# Altium schematic sheet CV_Inputs_Switches.SchDoc(CV_Inputs_Switches)
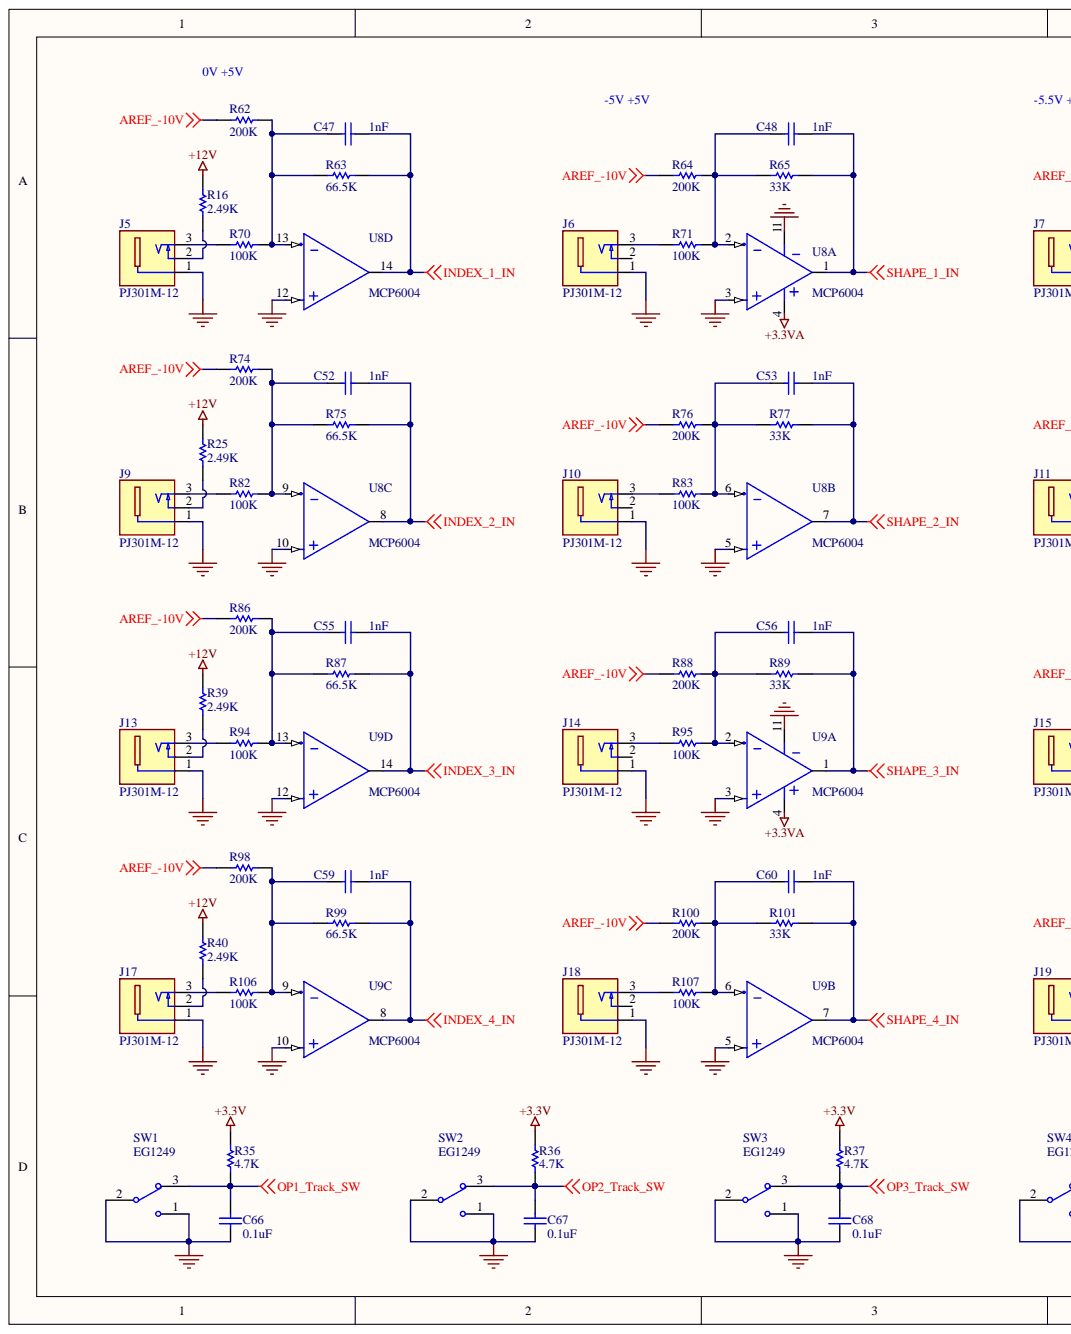
[diagram p0: CV_Inputs_Switches.SchDoc, left]
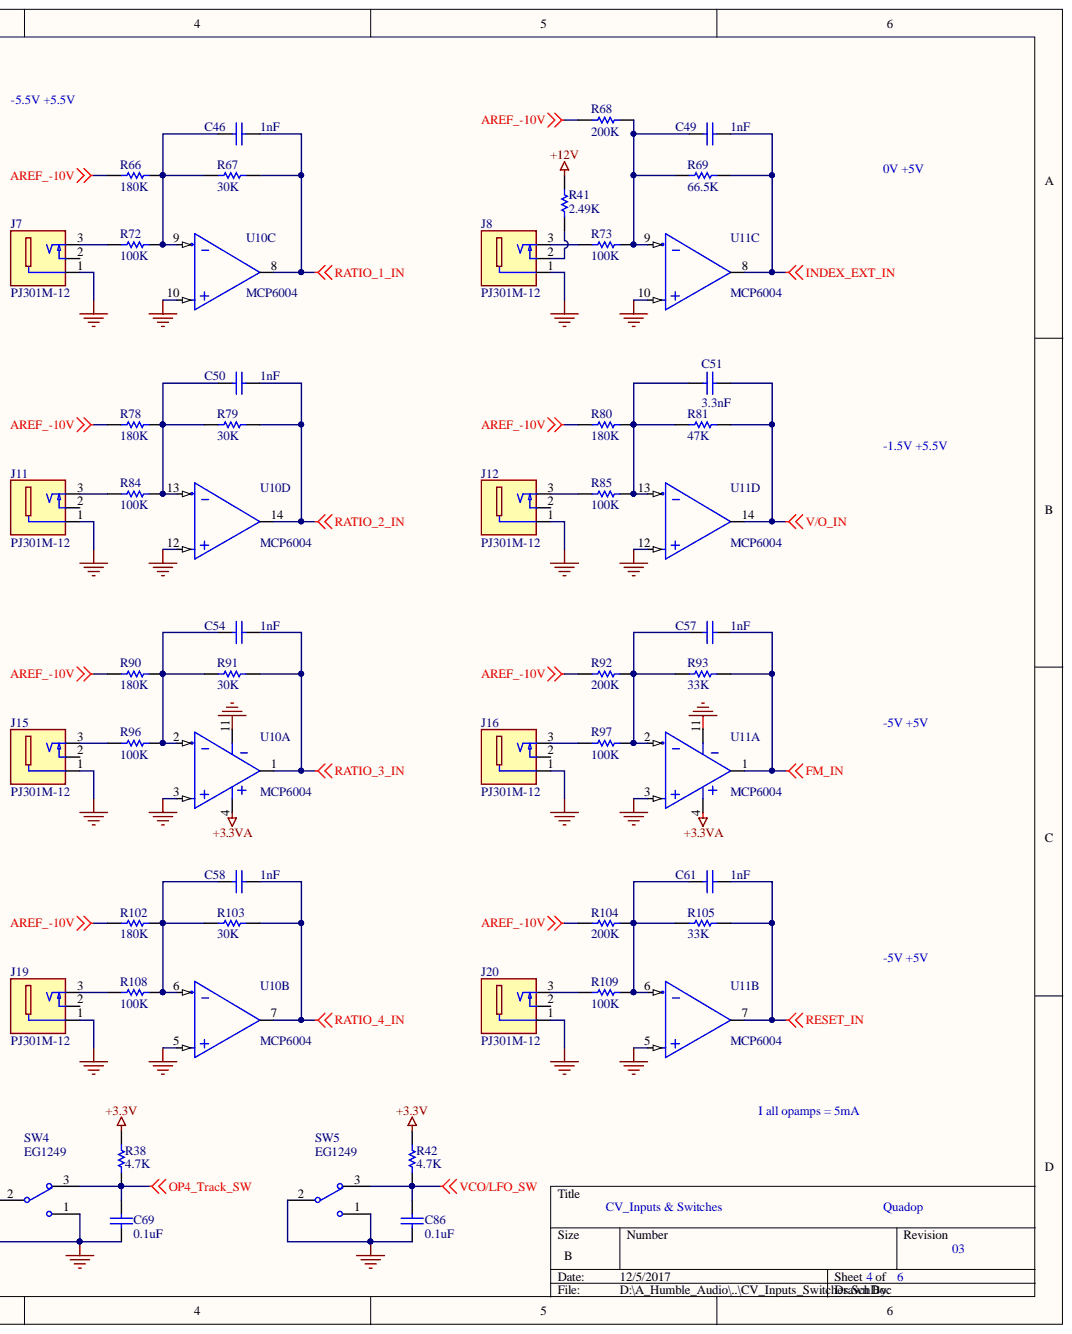
[diagram p1: CV_Inputs_Switches.SchDoc, right]

## Parts
C46  1nF  [C0603]
C47  1nF  [C0603]
C48  1nF  [C0603]
C49  1nF  [C0603]
C50  1nF  [C0603]
C51  3.3nF  [C0603]
C52  1nF  [C0603]
C53  1nF  [C0603]
C54  1nF  [C0603]
C55  1nF  [C0603]
C56  1nF  [C0603]
C57  1nF  [C0603]
C58  1nF  [C0603]
C59  1nF  [C0603]
C60  1nF  [C0603]
C61  1nF  [C0603]
C66  0.1uF  [C0603]
C67  0.1uF  [C0603]
C68  0.1uF  [C0603]
C69  0.1uF  [C0603]
C86  0.1uF  [C0603]
J5  PJ301M-12  [EP_J_3.5_PJ301M-12]
J6  PJ301M-12  [EP_J_3.5_PJ301M-12]
J7  PJ301M-12  [EP_J_3.5_PJ301M-12]
J8  PJ301M-12  [EP_J_3.5_PJ301M-12]
J9  PJ301M-12  [EP_J_3.5_PJ301M-12]
J10  PJ301M-12  [EP_J_3.5_PJ301M-12]
J11  PJ301M-12  [EP_J_3.5_PJ301M-12]
J12  PJ301M-12  [EP_J_3.5_PJ301M-12]
J13  PJ301M-12  [EP_J_3.5_PJ301M-12]
J14  PJ301M-12  [EP_J_3.5_PJ301M-12]
J15  PJ301M-12  [EP_J_3.5_PJ301M-12]
J16  PJ301M-12  [EP_J_3.5_PJ301M-12]
J17  PJ301M-12  [EP_J_3.5_PJ301M-12]
J18  PJ301M-12  [EP_J_3.5_PJ301M-12]
J19  PJ301M-12  [EP_J_3.5_PJ301M-12]
J20  PJ301M-12  [EP_J_3.5_PJ301M-12]
R16  2.49K  [R0603]
R25  2.49K  [R0603]
R35  4.7K  [R0603]
R36  4.7K  [R0603]
R37  4.7K  [R0603]
R38  4.7K  [R0603]
R39  2.49K  [R0603]
R40  2.49K  [R0603]
R41  2.49K  [R0603]
R42  4.7K  [R0603]
R62  200K  [R0603]
R63  66.5K  [R0603]
R64  200K  [R0603]
R65  33K  [R0603]
R66  180K  [R0603]
R67  30K  [R0603]
R68  200K  [R0603]
R69  66.5K  [R0603]
R70  100K  [R0603]
R71  100K  [R0603]
R72  100K  [R0603]
R73  100K  [R0603]
R74  200K  [R0603]
R75  66.5K  [R0603]
R76  200K  [R0603]
R77  33K  [R0603]
R78  180K  [R0603]
R79  30K  [R0603]
R80  180K  [R0603]
R81  47K  [R0603]
R82  100K  [R0603]
R83  100K  [R0603]
R84  100K  [R0603]
R85  100K  [R0603]
R86  200K  [R0603]
R87  66.5K  [R0603]
R88  200K  [R0603]
R89  33K  [R0603]
R90  180K  [R0603]
R91  30K  [R0603]
R92  200K  [R0603]
R93  33K  [R0603]
R94  100K  [R0603]
R95  100K  [R0603]
R96  100K  [R0603]
R97  100K  [R0603]
R98  200K  [R0603]
R99  66.5K  [R0603]
R100  200K  [R0603]
R101  33K  [R0603]
R102  180K  [R0603]
R103  30K  [R0603]
R104  200K  [R0603]
R105  33K  [R0603]
R106  100K  [R0603]
R107  100K  [R0603]
R108  100K  [R0603]
R109  100K  [R0603]
SW1  EG1249  [E-Switch_EG1249]
SW2  EG1249  [E-Switch_EG1249]
SW3  EG1249  [E-Switch_EG1249]
SW4  EG1249  [E-Switch_EG1249]
SW5  EG1249  [E-Switch_EG1249]
U8  MCP6004  [SO14]  (parts A,B,C,D)
U9  MCP6004  [SO14]  (parts A,B,C,D)
U10  MCP6004  [SO14]  (parts A,B,C,D)
U11  MCP6004  [SO14]  (parts A,B,C,D)

## Nets
+3.3V: R35.1 R36.1 R37.1 R38.1 R42.1
+3.3VA: U8.4 U9.4 U10.4 U11.4
+12V: R16.1 R25.1 R39.1 R40.1 R41.1
AREF_-10V: R62.1 R64.1 R66.1 R68.1 R74.1 R76.1 R78.1 R80.1 R86.1 R88.1 R90.1 R92.1 R98.1 R100.1 R102.1 R104.1
FM_IN: C57.2 R93.2 U11.1
GND: C66.2 C67.2 C68.2 C69.2 C86.2 J5.1 J6.1 J7.1 J8.1 J9.1 J10.1 J11.1 J12.1 J13.1 J14.1 J15.1 J16.1 J17.1 J18.1 J19.1 J20.1 SW1.1 SW1.2 SW2.1 SW2.2 SW3.1 SW3.2 SW4.1 SW4.2 SW5.1 SW5.2 U8.3 U8.5 U8.10 U8.11 U8.12 U9.3 U9.5 U9.10 U9.11 U9.12 U10.3 U10.5 U10.10 U10.11 U10.12 U11.3 U11.5 U11.10 U11.11 U11.12
INDEX_1_IN: C47.2 R63.2 U8.14
INDEX_2_IN: C52.2 R75.2 U8.8
INDEX_3_IN: C55.2 R87.2 U9.14
INDEX_4_IN: C59.2 R99.2 U9.8
INDEX_EXT_IN: C49.2 R69.2 U11.8
OP1_Track_SW: C66.1 R35.2 SW1.3
OP2_Track_SW: C67.1 R36.2 SW2.3
OP3_Track_SW: C68.1 R37.2 SW3.3
OP4_Track_SW: C69.1 R38.2 SW4.3
RATIO_1_IN: C46.2 R67.2 U10.8
RATIO_2_IN: C50.2 R79.2 U10.14
RATIO_3_IN: C54.2 R91.2 U10.1
RATIO_4_IN: C58.2 R103.2 U10.7
RESET_IN: C61.2 R105.2 U11.7
SHAPE_1_IN: C48.2 R65.2 U8.1
SHAPE_2_IN: C53.2 R77.2 U8.7
SHAPE_3_IN: C56.2 R89.2 U9.1
SHAPE_4_IN: C60.2 R101.2 U9.7
V/O_IN: C51.2 R81.2 U11.14
VCO/LFO_SW: C86.1 R42.2 SW5.3
NetC46_1: C46.1 R66.2 R67.1 R72.2 U10.9
NetC47_1: C47.1 R62.2 R63.1 R70.2 U8.13
NetC48_1: C48.1 R64.2 R65.1 R71.2 U8.2
NetC49_1: C49.1 R68.2 R69.1 R73.2 U11.9
NetC50_1: C50.1 R78.2 R79.1 R84.2 U10.13
NetC51_1: C51.1 R80.2 R81.1 R85.2 U11.13
NetC52_1: C52.1 R74.2 R75.1 R82.2 U8.9
NetC53_1: C53.1 R76.2 R77.1 R83.2 U8.6
NetC54_1: C54.1 R90.2 R91.1 R96.2 U10.2
NetC55_1: C55.1 R86.2 R87.1 R94.2 U9.13
NetC56_1: C56.1 R88.2 R89.1 R95.2 U9.2
NetC57_1: C57.1 R92.2 R93.1 R97.2 U11.2
NetC58_1: C58.1 R102.2 R103.1 R108.2 U10.6
NetC59_1: C59.1 R98.2 R99.1 R106.2 U9.9
NetC60_1: C60.1 R100.2 R101.1 R107.2 U9.6
NetC61_1: C61.1 R104.2 R105.1 R109.2 U11.6
NetJ5_2: J5.2 R16.2
NetJ5_3: J5.3 R70.1
NetJ6_3: J6.3 R71.1
NetJ7_3: J7.3 R72.1
NetJ8_2: J8.2 R41.2
NetJ8_3: J8.3 R73.1
NetJ9_2: J9.2 R25.2
NetJ9_3: J9.3 R82.1
NetJ10_3: J10.3 R83.1
NetJ11_3: J11.3 R84.1
NetJ12_3: J12.3 R85.1
NetJ13_2: J13.2 R39.2
NetJ13_3: J13.3 R94.1
NetJ14_3: J14.3 R95.1
NetJ15_3: J15.3 R96.1
NetJ16_3: J16.3 R97.1
NetJ17_2: J17.2 R40.2
NetJ17_3: J17.3 R106.1
NetJ18_3: J18.3 R107.1
NetJ19_3: J19.3 R108.1
NetJ20_3: J20.3 R109.1

Not connected: J6 2; J7 2; J10 2; J11 2; J12 2; J14 2; J15 2; J16 2; J18 2; J19 2; J20 2

## Library data of the parts
C46-C50 C52-C61 = 00102502: 1nF 50V 5% C0G 0603 -55+125; Manufacturer Part Number: C1608C0G1H102J080AA; Manufacturer: TDK; Tolerance: +-5%; PSpice: C; Database Table Name: Capacitor; Voltage: 50V; Value: 1nF; Tape Width: 8mm; SMD: Yes; Price: 0.0358; Part Type: Ceramic; Part Number: 00102502; Package: 4000T&R; Distributor Part Number: 445-1293-1-ND; Distributor: Digi-Key; Component Kind: Standard; Library Name: Gedo_DB.DbLib
C51 = 00332502: 3.3nF 50V 10% X7R 0603 -55+125; Manufacturer Part Number: CC0603KRX7R9BB332; Manufacturer: Yageo; Tolerance: +-10%; PSpice: C; Database Table Name: Capacitor; Voltage: 50V; Value: 3.3nF; Tape Width: 8mm; SMD: Yes; Price: 0.036; Part Type: Ceramic; Part Number: 00332502; Package: 4000T&R; Distributor Part Number: 311-1082-1-ND; Distributor: Digi-Key; Component Kind: Standard; Availability: In Stock; Library Name: Gedo_DB.DbLib
C66-C69 C86 = 00000538: 100nF 50V +-10% X7R 0603 -55+125; Manufacturer Part Number: GRM188R71H104KA93J; Manufacturer: MURATA; PSpice: C; Lead time: Stock; Database Table Name: Capacitor; Voltage: 50V; Value: 0.1uF; Tolerance: +-10%; Tape Width: 8mm; SMD: Yes; Price: 0.0048; Part Type: Ceramic; Part Number: 00000538; Package: 10000T&R330mm; MOQ: 4000; Main distributor: Rutronik; Distributor: Rutronik; Component Kind: Standard; Availability: In Stock; ActivepartsID: http://search.murata.co.jp/Ceramy/GrsearchViewAction.do;jsessionid=C4D720578E4D2E51EC807AFF78C1B5C3; Library Name: Gedo_DB.DbLib
J5-J20 = 72502011: 3pin EARPHONE JACK PANEL MOUNT VERTICAL F3.5; 0.5A 30V; Manufacturer: Thonkiconn; Database Table Name: Connectors; Value: PJ301M-12; Price: 0.48; Part Type: EarPhone jack F3.5; Part Number: 72502011; Manufacturer Part Number: PJ301M-12; Distributor: THONKICONN; Component Kind: Standard; Library Name: Gedo_DB.DbLib
R16 R25 R39-R41 = 11024912: 2K49 1% 0603 1/10W 100ppm; Manufacturer Part Number: RC0603FR-072K49L; Manufacturer: YAGEO; PSpice: R; Database Table Name: Resistor; Value: 2.49K; Tolerance: 1%; Tape Width: 8mm; SMD: Yes; Price: 0.012; Power: 1/10W; Part type: SMD 1%; Part Number: 11024912; Package: 5000T&R; Distributor Part Number: 311-2.49KHRTR-ND; Distributor: Digi-Key; Component Kind: Standard; Availability: In Stock; Library Name: Gedo_DB.DbLib
R35-R38 R42 = 11047012: 4K7 1% 0603 1/10W 100ppm; PSpice: R; Lead time: Stock; Database Table Name: Resistor; Value: 4.7K; Tolerance: 1%; Tape Width: 8mm; SMD: Yes; Power: 1/10W; Part type: SMD 1%; Part Number: 11047012; MOQ: 5000; Main distributor: Rutronik; Component Kind: Standard; Availability: In Stock; Library Name: Gedo_DB.DbLib
R62 R64 R68 R74 R76 R86 R88 R92 R98 R100 R104 = 11020032: 200K 1% 0603 1/10W 100ppm; Manufacturer: YAGEO; PSpice: R; Database Table Name: Resistor; Value: 200K; Tolerance: 1%; Tape Width: 8mm; SMD: Yes; Power: 1/10W; Part type: SMD 1%; Part Number: 11020032; Package: 5000T&R; Component Kind: Standard; Availability: In Stock; Library Name: Gedo_DB.DbLib
R63 R69 R75 R87 R99 = 11066522: 66K5 1% 0603 1/10W 100ppm; Manufacturer Part Number: RC0603FR-0766K5; Manufacturer: YAGEO; PSpice: R; Database Table Name: Resistor; Value: 66.5K; Tolerance: 1%; Tape Width: 8mm; SMD: Yes; Power: 1/10W; Part type: SMD 1%; Part Number: 11066522; Component Kind: Standard; Library Name: Gedo_DB.DbLib
R65 R77 R89 R93 R101 R105 = 11033022: 33K 1% 0603 1/10W 100ppm; Manufacturer Part Number: RC0603FR-0733KL; Manufacturer: YAGEO; PSpice: R; Database Table Name: Resistor; Value: 33K; Tolerance: 1%; Tape Width: 8mm; SMD: Yes; Price: 0.0057; Power: 1/10W; Part type: SMD 1%; Part Number: 11033022; Package: 5000T&R; Distributor Part Number: 311-33.0KHRCT-ND; Distributor: Digi-Key; Component Kind: Standard; Availability: In Stock; Library Name: Gedo_DB.DbLib
R66 R78 R80 R90 R102 = 11018032: 180K 1% 0603 1/10W 100ppm; Manufacturer Part Number: RC0603FR-07180KL; Manufacturer: YAGEO; PSpice: R; Database Table Name: Resistor; Value: 180K; Tolerance: 1%; Tape Width: 8mm; SMD: Yes; Price: 0.013; Power: 1/10W; Part type: SMD 1%; Part Number: 11018032; Package: 5000T&R; Distributor Part Number: 311-180KHRCT-ND; Distributor: Digi-Key; Component Kind: Standard; Availability: In Stock; Library Name: Gedo_DB.DbLib
R67 R79 R91 R103 = 11030022: 30K 1% 0603 1/10W 100ppm; Manufacturer Part Number: RC0603FR-0730KL; Manufacturer: YAGEO; PSpice: R; Lead time: Stock; Database Table Name: Resistor; Value: 30K; Tolerance: 1%; Tape Width: 8mm; SMD: Yes; Price: 0.013; Power: 1/10W; Part type: SMD 1%; Part Number: 11030022; Package: 5000T&R; MOQ: 5000; Distributor Part Number: 311-30.0KHRCT-ND; Distributor: Digi-Key; Component Kind: Standard; Availability: In Stock; Library Name: Gedo_DB.DbLib
R70-R73 R82-R85 R94-R97 R106-R109 = 11010032: 100K 1% 0603 1/10W 100ppm; Manufacturer Part Number: RC0603FR-07100KL; Manufacturer: YAGEO; PSpice: R; Database Table Name: Resistor; Value: 100K; Tolerance: 1%; Tape Width: 8mm; SMD: Yes; Price: 0.006; Power: 1/10W; Part type: SMD 1%; Part Number: 11010032; Package: 5000T&R; Distributor Part Number: 311-100KHRCT-ND; Distributor: Digi-Key; Component Kind: Standard; Availability: In Stock; Library Name: Gedo_DB.DbLib
R81 = 11047022: 47K 1% 0603 1/10W 100ppm; Manufacturer Part Number: RC0603FR-0747KL; Manufacturer: YAGEO; PSpice: R; Database Table Name: Resistor; Value: 47K; Tolerance: 1%; Tape Width: 8mm; SMD: Yes; Price: 0.006; Power: 1/10W; Part type: SMD 1%; Part Number: 11047022; Package: 5000T&R; Distributor Part Number: 311-47.0KHRCT-ND; Distributor: Digi-Key; Component Kind: Standard; Availability: In Stock; Library Name: Gedo_DB.DbLib
SW1-SW5 = 88100066: Slide Switch SPDT Vertical 100mA,12VDC,-20+70; Manufacturer: E-Switch; Database Table Name: Misc; Value: EG1249; Price: 0.563; Part Type: Switch\Slide; Part Number: 88100066; MOQ: 0; Manufacturer Part Number: EG1249; Distributor Part Number: 612-EG1249; Distributor: Mouser; Component Kind: Standard; Library Name: Gedo_DB.DbLib
U8-U11 = 51260041: Op amplifier,Rail-to-rail,Vs=1.8-6.0V,1MHz,Vo=0.6V/us,SO14,-40+85; Manufacturer Part Number: MCP6004-I/SL; Manufacturer: Microchip Technology; Database Table Name: IC; Value: MCP6004; Tape Width: 16mm; SMD: Yes; Price: 0.37; Part Type: OP Amplifiers; Part Number: 51260041; Package: 2600T&R; Distributor Part Number: MCP6004-I/SL-ND; Distributor: Digi-Key; Component Kind: Standard; Library Name: Gedo_DB.DbLib
